# Altium schematic sheet POWER_5V0.SchDoc(POWER_5V0)
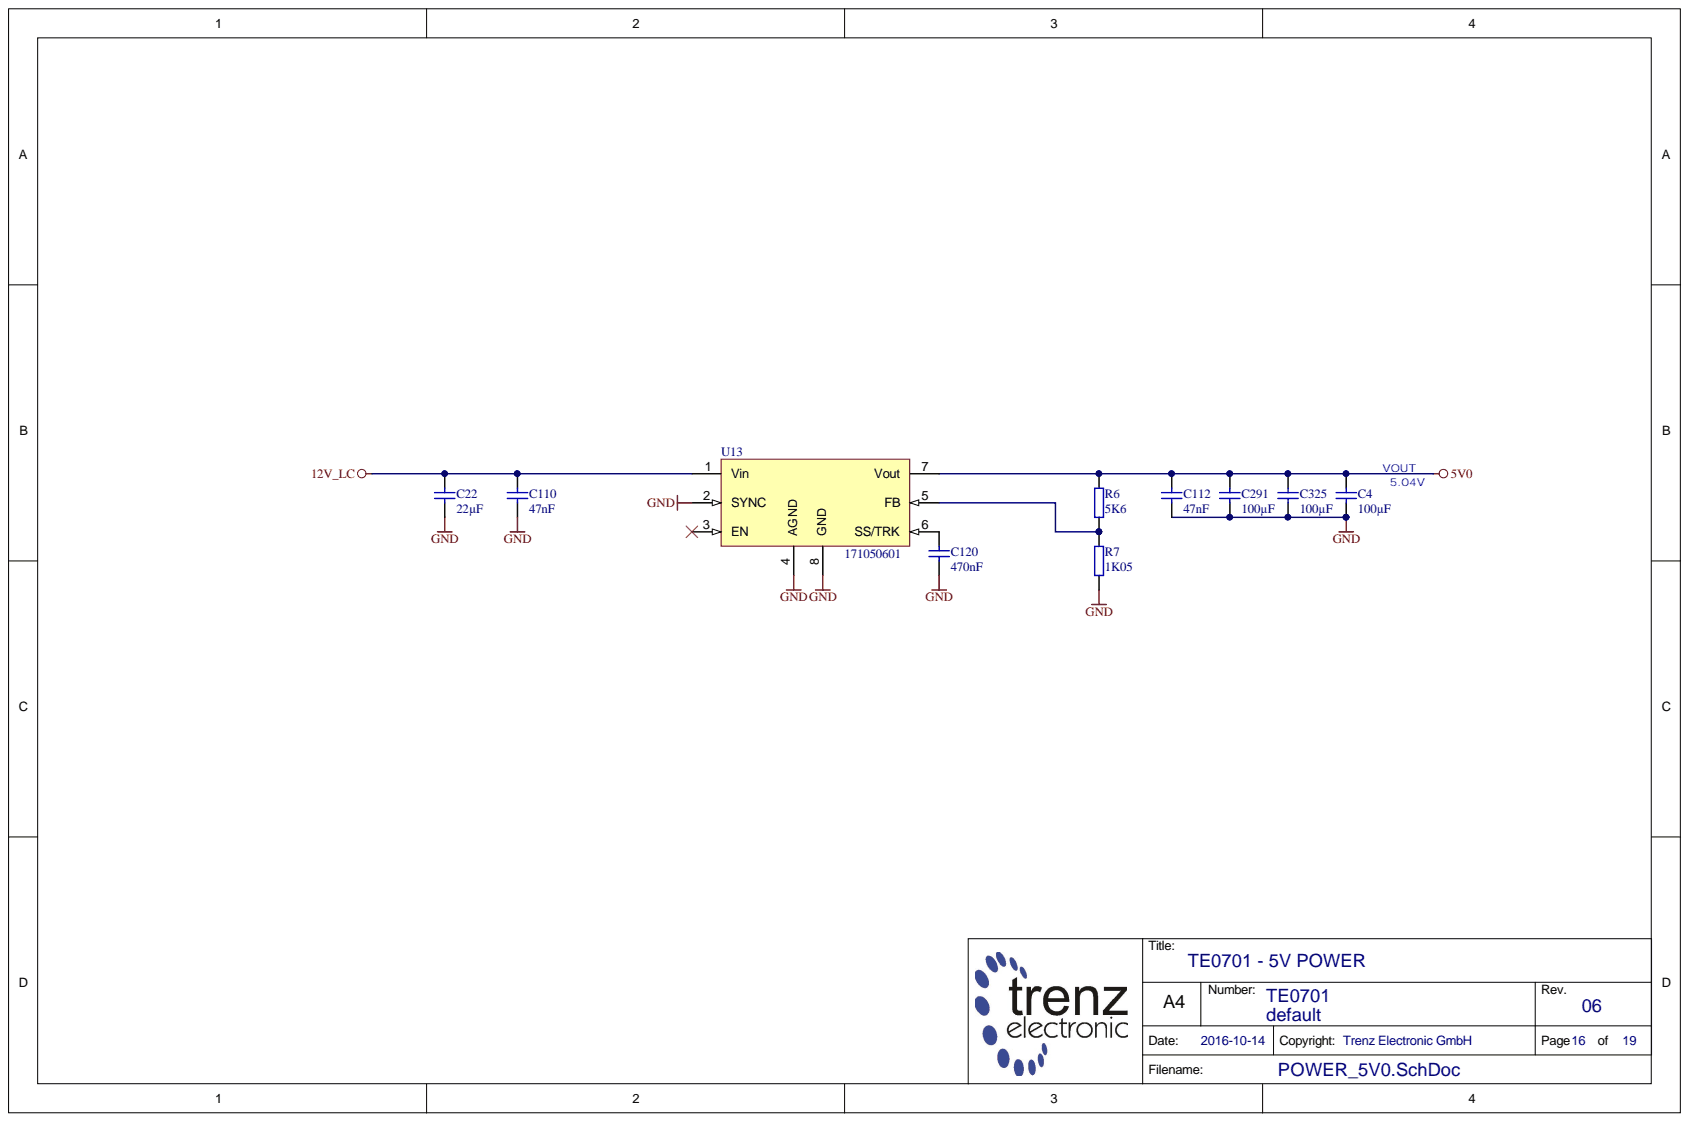
[diagram p0: POWER_5V0.SchDoc]

## Parts
C4  100µF  [CAPC3216X180N]
C22  22µF  [CAPC3216X180N]
C110  47nF  [CAPC1005X55N]
C112  47nF  [CAPC1005X55N]
C120  470nF  [CAPC1005X55N]
C291  100µF  [CAPC3216X180N]
C325  100µF  [CAPC3216X180N]
R6  5K6  [RESC1005X40N]
R7  1K05  [RESC1005X40N]
U13  171050601  [7TO-PMOD]

## Nets
5V0: C4.1 C112.2 C291.1 C325.1 R6.1 U13.7(Vout)
12V_LC: C22.2 C110.2 U13.1(Vin)
GND: C4.2 C22.1 C110.1 C112.1 C120.2 C291.2 C325.2 R7.2 U13.2(SYNC) U13.4(AGND) U13.8(GND)
NetC120_1: C120.1 U13.6(SS/TRK)
NetR6_2: R6.2 R7.1 U13.5(FB)

Not connected: U13 3(EN)

## Library data of the parts
C4 C291 C325 = 23116: KON 100µF 6,3V X5R SMD 20%; Database Table Name: C_3216_1206; Mixed: SMT; Preferred: Yes; Value: 100u; Voltage: 6.3V; AN: 23116; Case: 3216 (1206); Component Kind: Standard; Library Name: TE.DbLib; Technology: X5R; Tolerance: 20%
C22 = 24886: KON 22µF X5R 25V SMD 10%; Database Table Name: C_3216_1206; Mixed: SMT; Preferred: Yes; Value: 22u; AN: 24886; Case: 3216 (1206); Component Kind: Standard; Library Name: TE.DbLib; Tolerance: 10%; Voltage: 25V
C110 C112 = 24684: KON 47nF 50V X7R SMD 10%; Database Table Name: C_1005_0402; Mixed: SMT; Value: 47n; AN: 24684; Case: 1005 (0402); Component Kind: Standard; Library Name: TE.DbLib; Technology: X7R; Tolerance: 10%; Voltage: 50V
C120 = 23659: KON 470nF 6,3V X5R SMD; Database Table Name: C_1005_0402; Mixed: SMT; Preferred: Yes; Value: 470n; Voltage: 6.3V; AN: 23659; Case: 1005 (0402); Component Kind: Standard; Library Name: TE.DbLib; Technology: X5R
R6 = 22760: WID SMD 5K6 1% 0,063W; Database Table Name: R_1005_0402; Mixed: SMT; Value: 5.6K; AN: 22760; Case: 1005 (0402); Component Kind: Standard; Library Name: TE.DbLib; Technology: TK100; Tolerance: 1%
R7 = 25956: WID SMD 1K05 1% 0,063W; Database Table Name: R_1005_0402; Mixed: SMT; Value: 1.05K; AN: 25956; Case: 1005 (0402); Component Kind: Standard; Library Name: TE.DbLib; Technology: TK100; Tolerance: 1%
U13 = 26051: Database Table Name: DCDC; Mixed: SMT; AN: 26051; Case: TO263-7EP; Component Kind: Standard; Description: 171050601; H-ART: 171050601; Library Name: TE.DbLib; HelpURL: B:\AD\adlib\datasheet\171050601
